# Altium schematic sheet ST1-100-Top.SchDoc(ST1-100-Top)
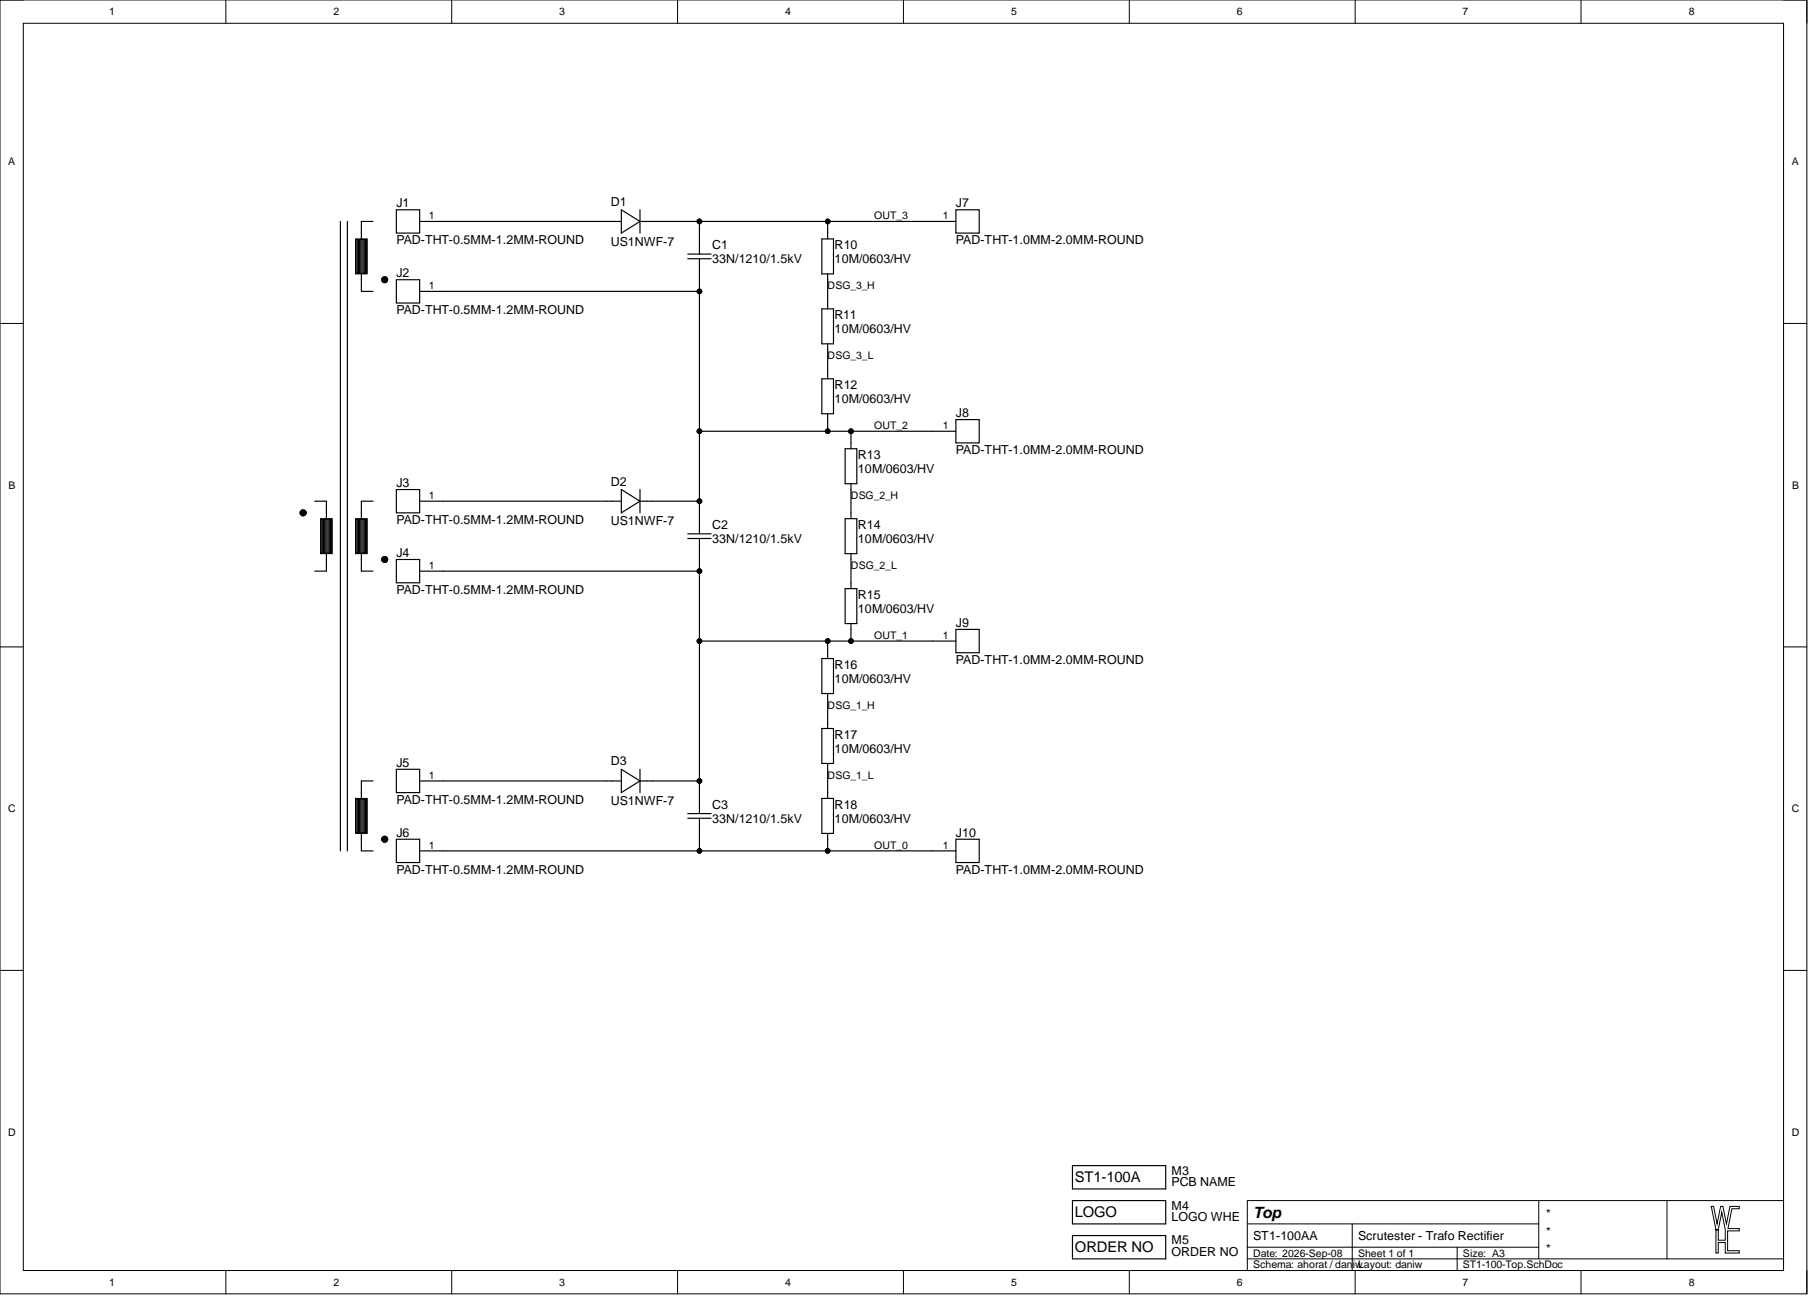
[diagram p0: ST1-100-Top.SchDoc]

## Parts
C1  33N/1210/1.5kV  [CAP1210_X7R]
C2  33N/1210/1.5kV  [CAP1210_X7R]
C3  33N/1210/1.5kV  [CAP1210_X7R]
D1  US1NWF-7  [SOD3718X125]
D2  US1NWF-7  [SOD3718X125]
D3  US1NWF-7  [SOD3718X125]
J1  PAD-THT-0.5MM-1.2MM-ROUND  [PAD-THT-0.5MM-1.2MM-ROUND]
J2  PAD-THT-0.5MM-1.2MM-ROUND  [PAD-THT-0.5MM-1.2MM-ROUND]
J3  PAD-THT-0.5MM-1.2MM-ROUND  [PAD-THT-0.5MM-1.2MM-ROUND]
J4  PAD-THT-0.5MM-1.2MM-ROUND  [PAD-THT-0.5MM-1.2MM-ROUND]
J5  PAD-THT-0.5MM-1.2MM-ROUND  [PAD-THT-0.5MM-1.2MM-ROUND]
J6  PAD-THT-0.5MM-1.2MM-ROUND  [PAD-THT-0.5MM-1.2MM-ROUND]
J7  PAD-THT-1.0MM-2.0MM-ROUND  [PAD-THT-1.0MM-2.0MM-ROUND]
J8  PAD-THT-1.0MM-2.0MM-ROUND  [PAD-THT-1.0MM-2.0MM-ROUND]
J9  PAD-THT-1.0MM-2.0MM-ROUND  [PAD-THT-1.0MM-2.0MM-ROUND]
J10  PAD-THT-1.0MM-2.0MM-ROUND  [PAD-THT-1.0MM-2.0MM-ROUND]
M3  PCB NAME  [PCB-NAME-2MM-CENTER]
M4  LOGO WHE  [LOGO-WHE-2MM]
M5  ORDER NO  [JLC-ORDER]
R10  10M/0603/HV  [R0603-0.40-WW]
R11  10M/0603/HV  [R0603-0.40-WW]
R12  10M/0603/HV  [R0603-0.40-WW]
R13  10M/0603/HV  [R0603-0.40-WW]
R14  10M/0603/HV  [R0603-0.40-WW]
R15  10M/0603/HV  [R0603-0.40-WW]
R16  10M/0603/HV  [R0603-0.40-WW]
R17  10M/0603/HV  [R0603-0.40-WW]
R18  10M/0603/HV  [R0603-0.40-WW]

## Nets
DSG_1_H: R16.2 R17.1
DSG_1_L: R17.2 R18.1
DSG_2_H: R13.2 R14.1
DSG_2_L: R14.2 R15.1
DSG_3_H: R10.2 R11.1
DSG_3_L: R11.2 R12.1
OUT_0: C3.2 J6.1 J10.1 R18.2
OUT_1: C2.2 C3.1 D3.1 J4.1 J9.1 R15.2 R16.1
OUT_2: C1.2 C2.1 D2.1 J2.1 J8.1 R12.2 R13.1
OUT_3: C1.1 D1.1 J7.1 R10.1
NetD1_2: D1.2 J1.1
NetD2_2: D2.2 J3.1
NetD3_2: D3.2 J5.1

## Library data of the parts
C1-C3 = 33N/1210/1.5kV: CAP SM 1210 33nF 1.5kV; Manufacturer: GENERIC; Manufacturer 1: Cal-Chip Electronics, Inc.; Manufacturer Part Number 1: CHV1210N1K5333KXT; Absolute Value: 3.3E-8; Database Table Name: C$; Component Kind: Standard; HelpURL: https://88ce57.p3cdn1.secureserver.net/wp-content/uploads/2023/01/chv_series-1.pdf; Package: 1210; Part Number: 33N/1210/1.5kV; Supplier 1: Digi-Key; Supplier Part Number 1: 4713-CHV1210N1K5333KXTCT-ND; Value: 33nF; Library Name: daniw_altium_lib.DbLib
D1-D3 = US1NWF-7: US1NWF 1.0A SURFACE MOUNT ULTRA-FAST RECTIFIER; Manufacturer: Diodes Incorporated; Absolute Value: 1200V; Database Table Name: D$; Component Kind: Standard; HelpURL: https://www.diodes.com/assets/Datasheets/US1NWF.pdf; JLC Type: Extended; Manufacturer 1: Diodes Incorporated; Manufacturer 3: DIODES; Manufacturer Part Number 1: US1NWF-7; Manufacturer Part Number 3: US1NWF-7; Package: SOD-123; Part Number: US1NWF-7; Supplier 1: Digikey; Supplier 3: LCSC; Supplier Part Number 1: US1NWF-7DICT-ND; Supplier Part Number 3: C5148544; Value: US1NWF-7; Library Name: daniw_altium_lib.DbLib
J1-J6 = PAD-THT-0.5MM-1.2MM-ROUND: Through-hole pad, 0.5mm drill, 1.2mm pad, round; Manufacturer: GENERIC; Database Table Name: CON$; System: Connector; Component Kind: Standard; Part Number: PAD-THT-0.5MM-1.2MM-ROUND; Library Name: daniw_altium_lib.DbLib
J7-J10 = PAD-THT-1.0MM-2.0MM-ROUND: Through-hole pad, 1.0mm drill, 2.0mm pad, round; Manufacturer: GENERIC; Database Table Name: CON$; System: Connector; Component Kind: Standard; Part Number: PAD-THT-1.0MM-2.0MM-ROUND; Library Name: daniw_altium_lib.DbLib
M3 = PCB NAME 2mm C: PCB name, 2mm text height, centered; Manufacturer: NONE; Database Table Name: Misc$; Component Kind: Standard; Part Number: PCB NAME 2mm C; Library Name: daniw_altium_lib.DbLib
M4 = LOGO WHE 2MM: WHE logo, size 2mm; Manufacturer: NONE; Database Table Name: Misc$; Component Kind: Standard; Part Number: LOGO WHE 2MM; Library Name: daniw_altium_lib.DbLib
M5 = ORDER NO JLC: Order number, JLC as per requirement; Manufacturer: NONE; Database Table Name: Misc$; Component Kind: Standard; Part Number: ORDER NO JLC; Library Name: daniw_altium_lib.DbLib
R10-R18 = 10M/0603/HV: RES SM 0603 10M High voltage; Manufacturer: GENERIC; Manufacturer 1: YAGEO; Manufacturer Part Number 1: RV0603FR-0710ML; Absolute Value: 10000000; Database Table Name: R$; Component Kind: Standard; HelpURL: https://www.yageogroup.com/content/datasheet/asset/file/PYU-RV_51_ROHS_L; JLC Type: Extended; Manufacturer 3: YAGEO; Manufacturer Part Number 3: RV0603FR-0710ML; Package: 0603; Part Number: 10M/0603/HV; Supplier 1: Digi-Key; Supplier 3: LCSC; Supplier Part Number 1: 13-RV0603FR-0710MLCT-ND; Supplier Part Number 3: C873271; Value: 10M; Library Name: daniw_altium_lib.DbLib
